# Altium schematic sheet Main Board-main_board_control-v2.0.0.SchDoc("Main Board-main_board_control-v2.0.0")
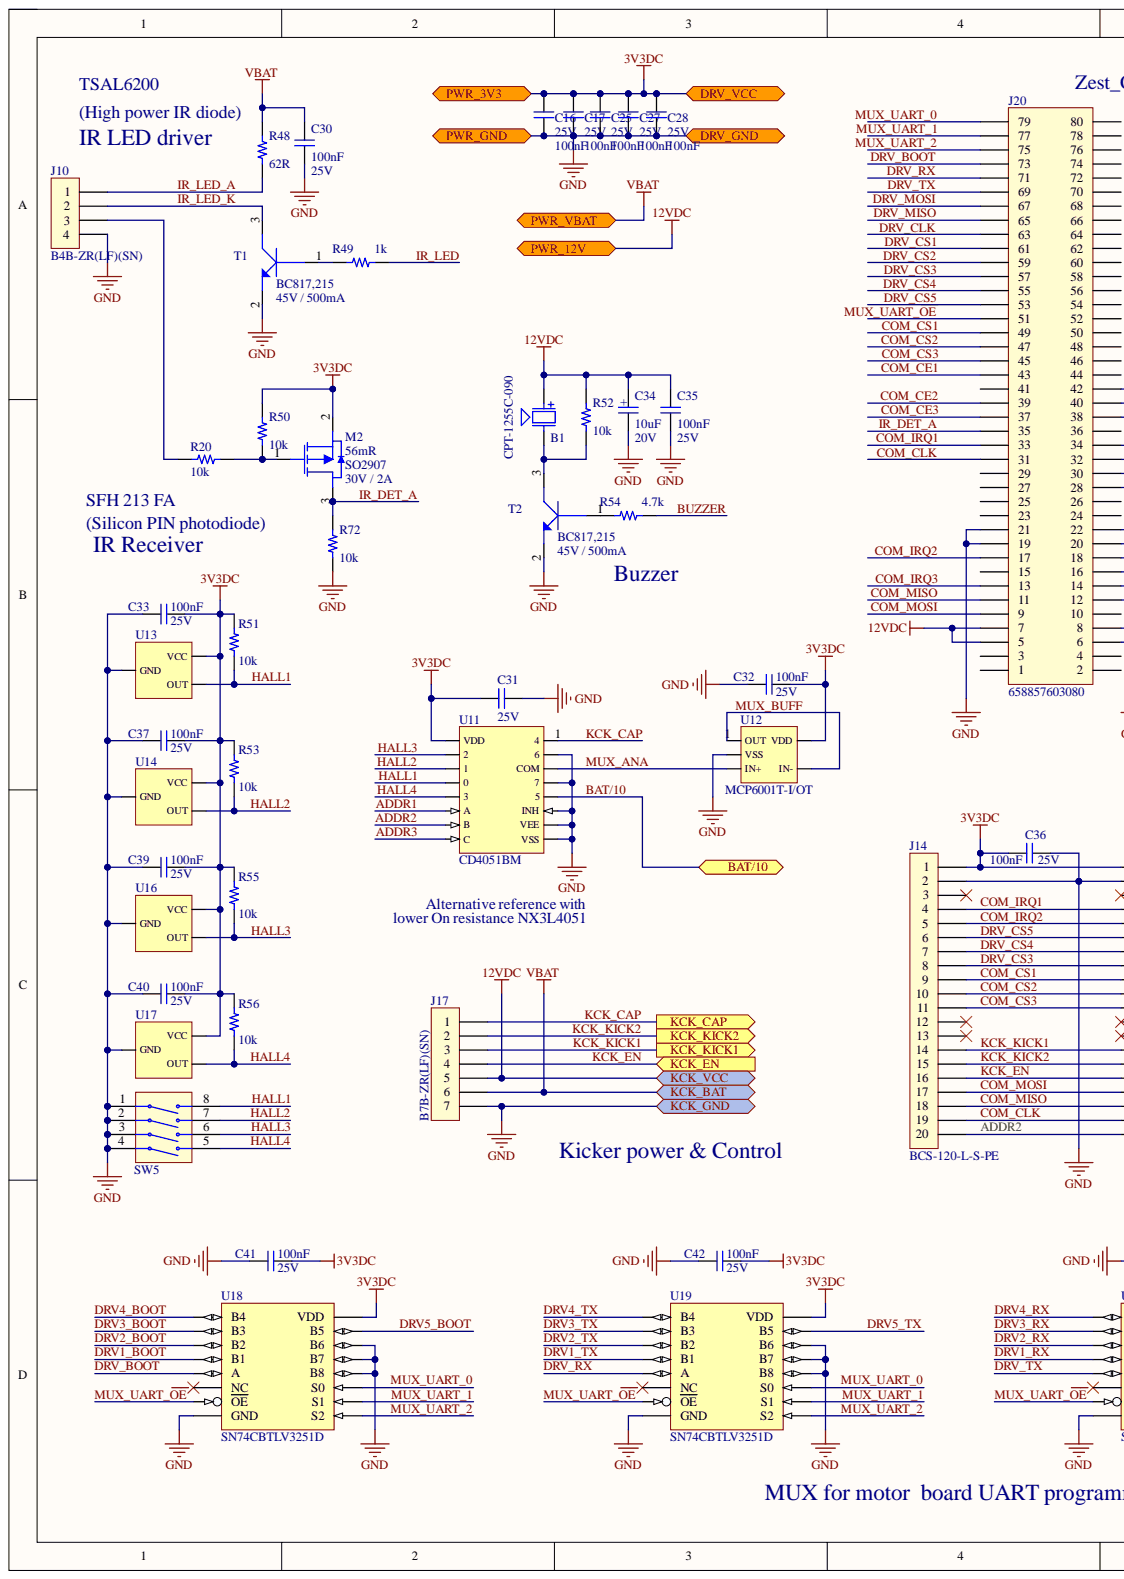
[diagram p0: Main Board-main_board_control-v2.0.0.SchDoc, left]
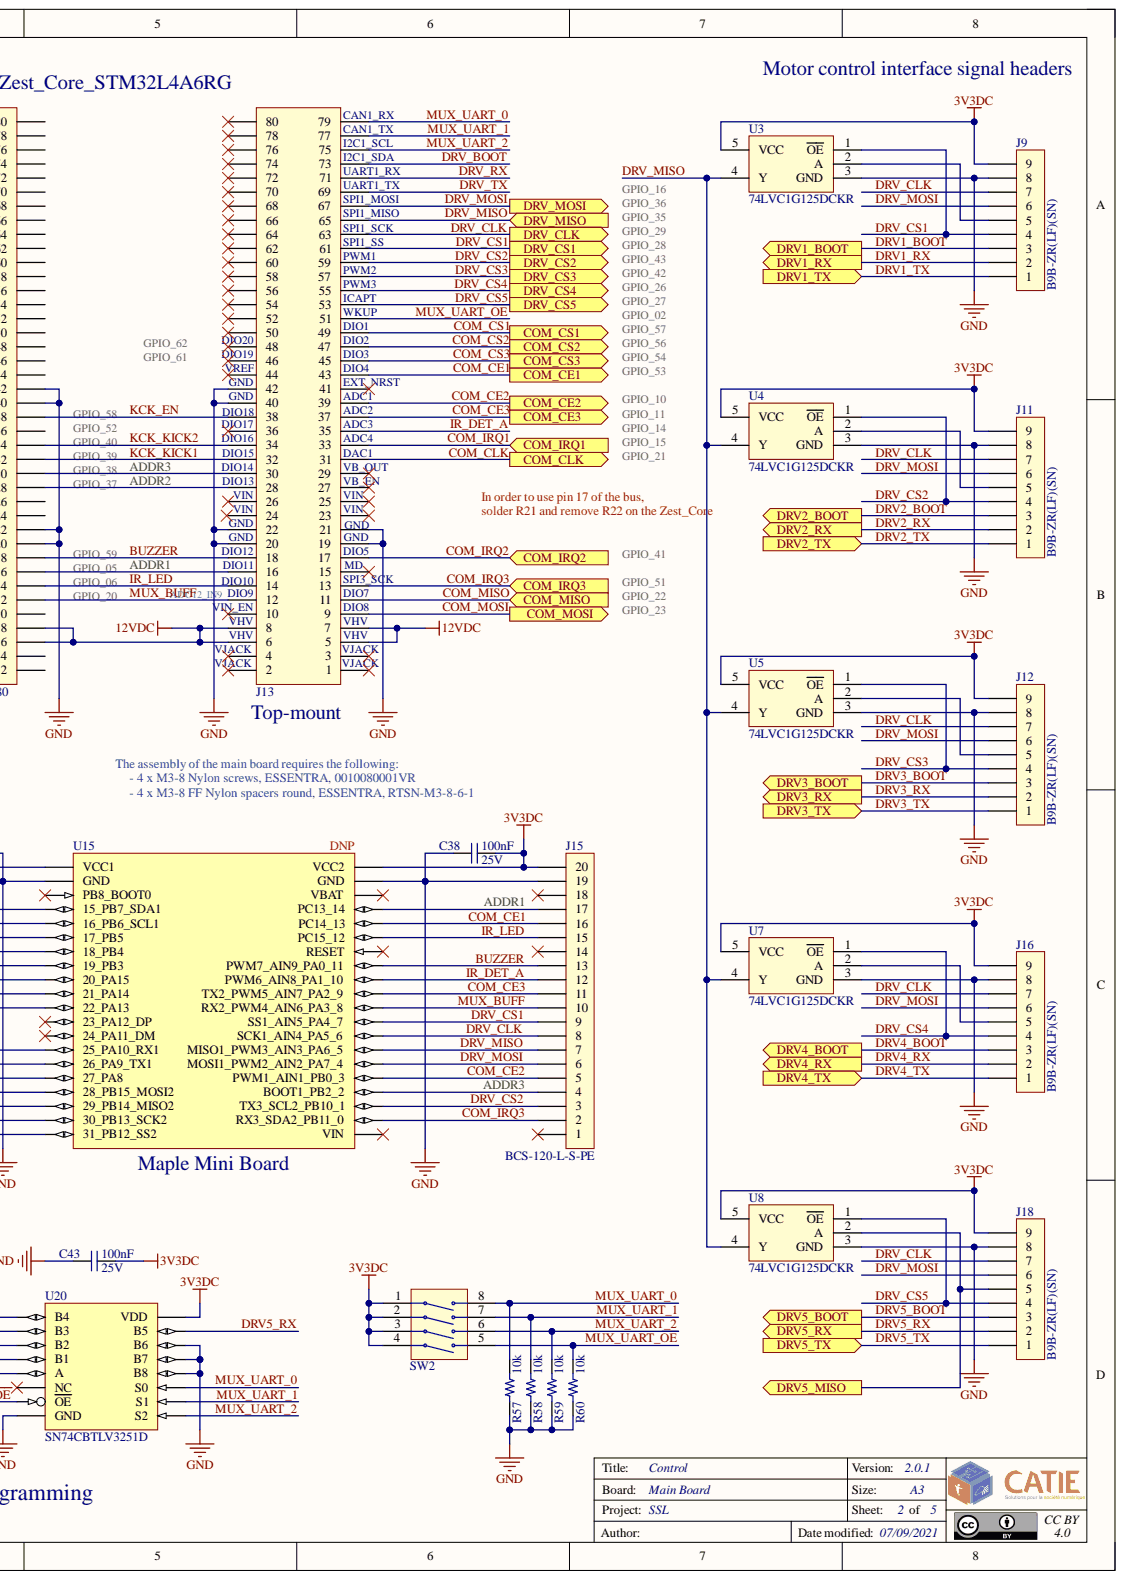
[diagram p1: Main Board-main_board_control-v2.0.0.SchDoc, right]

## Parts
B1  Reference: CPT-1255C-090  [BUZZER_CPT-1255C-090 _PIEZO_12MM]  (hidden comment: BUZZER_CPT-1255C-090 _PIEZO_12MM)
C16  value 100nF  Rating: 25V  [CAP_CER_0603]  (hidden comment: CAP_CER_0603)
C17  value 100nF  Rating: 25V  [CAP_CER_0603]  (hidden comment: CAP_CER_0603)
C25  value 100nF  Rating: 25V  [CAP_CER_0603]  (hidden comment: CAP_CER_0603)
C27  value 100nF  Rating: 25V  [CAP_CER_0603]  (hidden comment: CAP_CER_0603)
C28  value 100nF  Rating: 25V  [CAP_CER_0603]  (hidden comment: CAP_CER_0603)
C30  value 100nF  Rating: 25V  [CAP_CER_0603]  (hidden comment: CAP_CER_0603)
C31  Rating: 25V  [CAP_CER_0603]  (hidden comment: CAP_CER_0603)
C32  value 100nF  Rating: 25V  [CAP_CER_0603]  (hidden comment: CAP_CER_0603)
C33  value 100nF  Rating: 25V  [CAP_CER_0603]  (hidden comment: CAP_CER_0603)
C34  value 10uF  Rating: 20V  [CAP_TAN_A (1206)]  (hidden comment: CAP_TAN_A)
C35  value 100nF  Rating: 25V  [CAP_CER_0603]  (hidden comment: CAP_CER_0603)
C36  value 100nF  Rating: 25V  [CAP_CER_0603]  (hidden comment: CAP_CER_0603)
C37  value 100nF  Rating: 25V  [CAP_CER_0603]  (hidden comment: CAP_CER_0603)
C38  value 100nF  Rating: 25V  [CAP_CER_0603]  (hidden comment: CAP_CER_0603)
C39  value 100nF  Rating: 25V  [CAP_CER_0603]  (hidden comment: CAP_CER_0603)
C40  value 100nF  Rating: 25V  [CAP_CER_0603]  (hidden comment: CAP_CER_0603)
C41  value 100nF  Rating: 25V  [CAP_CER_0603]  (hidden comment: CAP_CER_0603)
C42  value 100nF  Rating: 25V  [CAP_CER_0603]  (hidden comment: CAP_CER_0603)
C43  value 100nF  Rating: 25V  [CAP_CER_0603]  (hidden comment: CAP_CER_0603)
J9  Reference: B9B-ZR(LF)(SN)  [HEADER_JST_B9B-ZR_1.5MM-PITCH_9-PIN_TOP]  (hidden comment: HEADER_JST_B9B-ZR_1.5MM-PITCH_9-PIN_TOP)
J10  Reference: B4B-ZR(LF)(SN)  [HEADER_JST_B4B-ZR_1.5MM-PITCH_4-PIN_TOP]  (hidden comment: HEADER_JST_B4B-ZR_1.5MM-PITCH_4-PIN_TOP)
J11  Reference: B9B-ZR(LF)(SN)  [HEADER_JST_B9B-ZR_1.5MM-PITCH_9-PIN_TOP]  (hidden comment: HEADER_JST_B9B-ZR_1.5MM-PITCH_9-PIN_TOP)
J12  Reference: B9B-ZR(LF)(SN)  [HEADER_JST_B9B-ZR_1.5MM-PITCH_9-PIN_TOP]  (hidden comment: HEADER_JST_B9B-ZR_1.5MM-PITCH_9-PIN_TOP)
J13  HEADER_FCI_10144517-081802LF_RECEPTACLE_3.7mm_6TRON  [HEADER_FCI_10144517-081802LF_RECEPTACLE_3.7mm_6TRON]  (value not drawn)
J14  Reference: BCS-120-L-S-PE  [HEADER_SAMTEC_BCS-120-L-S-PE_SOCKET_.54MM-PITCH_20-PIN]  (hidden comment: HEADER_SAMTEC_BCS-120-L-S-PE_SOCKET_.54MM-PITCH_20-PIN)
J15  Reference: BCS-120-L-S-PE  [HEADER_SAMTEC_BCS-120-L-S-PE_SOCKET_.54MM-PITCH_20-PIN]  (hidden comment: HEADER_SAMTEC_BCS-120-L-S-PE_SOCKET_.54MM-PITCH_20-PIN)
J16  Reference: B9B-ZR(LF)(SN)  [HEADER_JST_B9B-ZR_1.5MM-PITCH_9-PIN_TOP]  (hidden comment: HEADER_JST_B9B-ZR_1.5MM-PITCH_9-PIN_TOP)
J17  Reference: B7B-ZR(LF)(SN)  [HEADER_JST_B7B-ZR_1.5MM-PITCH_7-PIN_TOP]  (hidden comment: HEADER_JST_B7B-ZR_1.5MM-PITCH_7-PIN_TOP)
J18  Reference: B9B-ZR(LF)(SN)  [HEADER_JST_B9B-ZR_1.5MM-PITCH_9-PIN_TOP]  (hidden comment: HEADER_JST_B9B-ZR_1.5MM-PITCH_9-PIN_TOP)
J20  Reference: 658857603080  [HEADER_WE_658857603080_PLUG_7.6mm]  (hidden comment: HEADER_WE_658857603080_PLUG_7.6mm)
M2  value 56mR  Rating: 30V / 2A  Reference: SO2907  [TR_MOSFET-P_ST_STR2P3LLH6_SOT233]  (hidden comment: TR_MOSFET-P_ST_STR2P3LLH6_SOT233)
R20  value 10k  [RES_0603]  (hidden comment: RES_0603)
R48  value 62R  [RES_0603]  (hidden comment: RES_0603)
R49  value 1k  [RES_0603]  (hidden comment: RES_0603)
R50  value 10k  [RES_0603]  (hidden comment: RES_0603)
R51  value 10k  [RES_0603]  (hidden comment: RES_0603)
R52  value 10k  [RES_0603]  (hidden comment: RES_0603)
R53  value 10k  [RES_0603]  (hidden comment: RES_0603)
R54  value 4.7k  [RES_0603]  (hidden comment: RES_0603)
R55  value 10k  [RES_0603]  (hidden comment: RES_0603)
R56  value 10k  [RES_0603]  (hidden comment: RES_0603)
R57  value 10k  [RES_0603]  (hidden comment: RES_0603)
R58  value 10k  [RES_0603]  (hidden comment: RES_0603)
R59  value 10k  [RES_0603]  (hidden comment: RES_0603)
R60  value 10k  [RES_0603]  (hidden comment: RES_0603)
R72  value 10k  [RES_0603]  (hidden comment: RES_0603)
SW2  SWITCH_SMD 418121270804_WE_QUAD  [SWITCH_SMD 418121270804_WE_QUAD]  (value not drawn)
SW5  SWITCH_SMD 418121270804_WE_QUAD  [SWITCH_SMD 418121270804_WE_QUAD]  (value not drawn)
T1  Rating: 45V / 500mA  Reference: BC817,215  [TR_BC817_NPN_SOT23-3]  (hidden comment: TR_BC817_NPN_SOT23-3)
T2  Rating: 45V / 500mA  Reference: BC817,215  [TR_BC817_NPN_SOT23-3]  (hidden comment: TR_BC817_NPN_SOT23-3)
U3  Reference: 74LVC1G125DCKR  [IC_74LVC1G125DCK_SOT353_SC70-5]  (hidden comment: IC_74LVC1G125DCK_SOT353_SC70-5)
U4  Reference: 74LVC1G125DCKR  [IC_74LVC1G125DCK_SOT353_SC70-5]  (hidden comment: IC_74LVC1G125DCK_SOT353_SC70-5)
U5  Reference: 74LVC1G125DCKR  [IC_74LVC1G125DCK_SOT353_SC70-5]  (hidden comment: IC_74LVC1G125DCK_SOT353_SC70-5)
U7  Reference: 74LVC1G125DCKR  [IC_74LVC1G125DCK_SOT353_SC70-5]  (hidden comment: IC_74LVC1G125DCK_SOT353_SC70-5)
U8  Reference: 74LVC1G125DCKR  [IC_74LVC1G125DCK_SOT353_SC70-5]  (hidden comment: IC_74LVC1G125DCK_SOT353_SC70-5)
U11  Reference: CD4051BM  [IC_CD4051BM_TI_ANALOG_MUX_SOIC16]  (hidden comment: IC_CD4051BM_TI_ANALOG_MUX_SOIC16)
U12  Reference: MCP6001T-I/OT  [IC_MCP6001_OPAMP_RRIO_SOT23-5]  (hidden comment: IC_MCP6001_OPAMP_RRIO_SOT23-5)
U13  IC_DRV5023_TEXAS_HALL_SWITCH_SENSOR_SOT233  [IC_DRV5023_TEXAS_HALL_SWITCH_SENSOR_SOT233]  (value not drawn)
U14  IC_DRV5023_TEXAS_HALL_SWITCH_SENSOR_SOT233  [IC_DRV5023_TEXAS_HALL_SWITCH_SENSOR_SOT233]  (value not drawn)
U15  Mount: DNP  [MODULE_MAPLE-MINI_V2]  (hidden comment: MODULE_MAPLE-MINI)
U16  IC_DRV5023_TEXAS_HALL_SWITCH_SENSOR_SOT233  [IC_DRV5023_TEXAS_HALL_SWITCH_SENSOR_SOT233]  (value not drawn)
U17  IC_DRV5023_TEXAS_HALL_SWITCH_SENSOR_SOT233  [IC_DRV5023_TEXAS_HALL_SWITCH_SENSOR_SOT233]  (value not drawn)
U18  Reference: SN74CBTLV3251D  [IC_SN74CBTLV3251D_Multiplexer-DEMULTIPLEXER_8-CHANNEL_SOIC16]  (hidden comment: IC_SN74CBTLV3251D_Multiplexer-DEMULTIPLEXER_8-CHANNEL_SOIC16)
U19  Reference: SN74CBTLV3251D  [IC_SN74CBTLV3251D_Multiplexer-DEMULTIPLEXER_8-CHANNEL_SOIC16]  (hidden comment: IC_SN74CBTLV3251D_Multiplexer-DEMULTIPLEXER_8-CHANNEL_SOIC16)
U20  Reference: SN74CBTLV3251D  [IC_SN74CBTLV3251D_Multiplexer-DEMULTIPLEXER_8-CHANNEL_SOIC16]  (hidden comment: IC_SN74CBTLV3251D_Multiplexer-DEMULTIPLEXER_8-CHANNEL_SOIC16)

## Ports
BAT/10, COM_CE1, COM_CE2, COM_CE3, COM_CLK, COM_CS1, COM_CS2, COM_CS3, COM_IRQ1, COM_IRQ2, COM_IRQ3, COM_MISO, COM_MOSI, DRV1_BOOT, DRV1_RX, DRV1_TX, DRV2_BOOT, DRV2_RX, DRV2_TX, DRV3_BOOT, DRV3_RX, DRV3_TX, DRV4_BOOT, DRV4_RX, DRV4_TX, DRV5_BOOT, DRV5_MISO, DRV5_RX, DRV5_TX, DRV_CLK, DRV_CS1, DRV_CS2, DRV_CS3, DRV_CS4, DRV_CS5, DRV_GND, DRV_MISO, DRV_MOSI, DRV_VCC, KCK_BAT, KCK_CAP, KCK_EN, KCK_GND, KCK_KICK1, KCK_KICK2, KCK_VCC, PWR_3V3, PWR_12V, PWR_GND, PWR_VBAT

## Nets
3V3DC: C16.1 C17.1 C25.1 C27.1 C28.1 C31.1 C32.1 C33.1 C36.1 C37.1 C38.1 C39.1 C40.1 C41.1 C42.1 C43.1 J9.9 J11.9 J12.9 J14.1 J15.20 J16.9 J18.9 M2.2 R50.2 R51.2 R53.2 R55.2 R56.2 SW2.1 SW2.2 SW2.3 SW2.4 U3.5(VCC) U4.5(VCC) U5.5(VCC) U7.5(VCC) U8.5(VCC) U11.16(VDD) U12.5(VDD) U13.1 U14.1 U15.1(VCC1) U15.40(VCC2) U16.1 U17.1 U18.16(VDD) U19.16(VDD) U20.16(VDD) ; port DRV_VCC, port PWR_3V3
12VDC: B1.1 C34.2 C35.2 J13.5 J13.6 J13.7 J13.8 J17.5 J20.5 J20.6 J20.7 J20.8 R52.2 ; port KCK_VCC, port PWR_12V
ADDR1: J13.16 J15.17 J20.16 U11.11(A) U15.37(PC13_14)
ADDR2: J13.28 J14.20 J20.28 U11.10(B) U15.20(31_PB12_SS2)
ADDR3: J13.30 J15.4 J20.30 U11.9(C) U15.24(BOOT1_PB2_2)
BAT/10: U11.5 ; port BAT/10
BUZZER: J13.18 J15.13 J20.18 R54.2 U15.33(PWM7_AIN9_PA0_11)
COM_CE1: J13.43 J15.16 J20.43 U15.36(PC14_13) ; port COM_CE1
COM_CE2: J13.39 J15.5 J20.39 U15.25(PWM1_AIN1_PB0_3) ; port COM_CE2
COM_CE3: J13.37 J15.11 J20.37 U15.31(TX2_PWM5_AIN7_PA2_9) ; port COM_CE3
COM_CLK: J13.31 J14.19 J20.31 U15.19(30_PB13_SCK2) ; port COM_CLK
COM_CS1: J13.49 J14.9 J20.49 U15.9(20_PA15) ; port COM_CS1
COM_CS2: J13.47 J14.10 J20.47 U15.10(21_PA14) ; port COM_CS2
COM_CS3: J13.45 J14.11 J20.45 U15.11(22_PA13) ; port COM_CS3
COM_IRQ1: J13.33 J14.4 J20.33 U15.4(15_PB7_SDA1) ; port COM_IRQ1
COM_IRQ2: J13.17 J14.5 J20.17 U15.5(16_PB6_SCL1) ; port COM_IRQ2
COM_IRQ3: J13.13 J15.2 J20.13 U15.22(RX3_SDA2_PB11_0) ; port COM_IRQ3
COM_MISO: J13.11 J14.18 J20.11 U15.18(29_PB14_MISO2) ; port COM_MISO
COM_MOSI: J13.9 J14.17 J20.9 U15.17(28_PB15_MOSI2) ; port COM_MOSI
DRV1_BOOT: J9.3 U18.4(B1) ; port DRV1_BOOT
DRV1_RX: J9.2 U20.4(B1) ; port DRV1_RX
DRV1_TX: J9.1 U19.4(B1) ; port DRV1_TX
DRV2_BOOT: J11.3 U18.3(B2) ; port DRV2_BOOT
DRV2_RX: J11.2 U20.3(B2) ; port DRV2_RX
DRV2_TX: J11.1 U19.3(B2) ; port DRV2_TX
DRV3_BOOT: J12.3 U18.2(B3) ; port DRV3_BOOT
DRV3_RX: J12.2 U20.2(B3) ; port DRV3_RX
DRV3_TX: J12.1 U19.2(B3) ; port DRV3_TX
DRV4_BOOT: J16.3 U18.1(B4) ; port DRV4_BOOT
DRV4_RX: J16.2 U20.1(B4) ; port DRV4_RX
DRV4_TX: J16.1 U19.1(B4) ; port DRV4_TX
DRV5_BOOT: J18.3 U18.15(B5) ; port DRV5_BOOT
DRV5_MISO: J18.5 U8.2(A) ; port DRV5_MISO
DRV5_RX: J18.2 U20.15(B5) ; port DRV5_RX
DRV5_TX: J18.1 U19.15(B5) ; port DRV5_TX
DRV_BOOT: J13.73 J20.73 U18.5(A)
DRV_CLK: J9.7 J11.7 J12.7 J13.63 J15.8 J16.7 J18.7 J20.63 U15.28(SCK1_AIN4_PA5_6) ; port DRV_CLK
DRV_CS1: J9.4 J13.61 J15.9 J20.61 U3.1(OE) U15.29(SS1_AIN5_PA4_7) ; port DRV_CS1
DRV_CS2: J11.4 J13.59 J15.3 J20.59 U4.1(OE) U15.23(TX3_SCL2_PB10_1) ; port DRV_CS2
DRV_CS3: J12.4 J13.57 J14.8 J20.57 U5.1(OE) U15.8(19_PB3) ; port DRV_CS3
DRV_CS4: J13.55 J14.7 J16.4 J20.55 U7.1(OE) U15.7(18_PB4) ; port DRV_CS4
DRV_CS5: J13.53 J14.6 J18.4 J20.53 U8.1(OE) U15.6(17_PB5) ; port DRV_CS5
DRV_MISO: J13.65 J15.7 J20.65 U3.4(Y) U4.4(Y) U5.4(Y) U7.4(Y) U8.4(Y) U15.27(MISO1_PWM3_AIN3_PA6_5) ; port DRV_MISO
DRV_MOSI: J9.6 J11.6 J12.6 J13.67 J15.6 J16.6 J18.6 J20.67 U15.26(MOSI1_PWM2_AIN2_PA7_4) ; port DRV_MOSI
DRV_RX: J13.71 J20.71 U19.5(A)
DRV_TX: J13.69 J20.69 U20.5(A)
GND: C16.2 C17.2 C25.2 C27.2 C28.2 C30.1 C31.2 C32.2 C33.2 C34.1 C35.1 C36.2 C37.2 C38.2 C39.2 C40.2 C41.2 C42.2 C43.2 J9.8 J10.4 J11.8 J12.8 J13.19 J13.20 J13.21 J13.22 J13.40 J13.42 J14.2 J15.19 J16.8 J17.7 J18.8 J20.19 J20.20 J20.21 J20.22 J20.40 J20.42 R57.1 R58.1 R59.1 R60.1 R72.1 SW5.1 SW5.2 SW5.3 SW5.4 T1.2 T2.2 U3.3(GND) U4.3(GND) U5.3(GND) U7.3(GND) U8.3(GND) U11.2 U11.4 U11.6(INH) U11.7(VEE) U11.8(VSS) U12.2(VSS) U13.3 U14.3 U15.2(GND) U15.39(GND) U16.3 U17.3 U18.8(GND) U18.12(B8) U18.13(B7) U18.14(B6) U19.8(GND) U19.12(B8) U19.13(B7) U19.14(B6) U20.8(GND) U20.12(B8) U20.13(B7) U20.14(B6) ; port DRV_GND, port KCK_GND, port PWR_GND
HALL1: R51.1 SW5.8 U11.13 U13.2
HALL2: R53.1 SW5.7 U11.14 U14.2
HALL3: R55.1 SW5.6 U11.15 U16.2
HALL4: R56.1 SW5.5 U11.12 U17.2
IR_DET_A: J13.35 J15.12 J20.35 M2.3 R72.2 U15.32(PWM6_AIN8_PA1_10)
IR_LED: J13.14 J15.15 J20.14 R49.2 U15.35(PC15_12)
IR_LED_A: J10.1 R48.1
KCK_CAP: J17.1 U11.1 ; port KCK_CAP
KCK_EN: J13.38 J14.16 J17.4 J20.38 U15.16(27_PA8) ; port KCK_EN
KCK_KICK1: J13.32 J14.14 J17.3 J20.32 U15.14(25_PA10_RX1) ; port KCK_KICK1
KCK_KICK2: J13.34 J14.15 J17.2 J20.34 U15.15(26_PA9_TX1) ; port KCK_KICK2
MUX_ANA: U11.3(COM) U12.3(IN+)
MUX_BUFF: J13.12 J15.10 J20.12 U12.1(OUT) U12.4(IN-) U15.30(RX2_PWM4_AIN6_PA3_8)
MUX_UART_0: J13.79 J20.79 R57.2 SW2.8 U18.11(S0) U19.11(S0) U20.11(S0)
MUX_UART_1: J13.77 J20.77 R58.2 SW2.7 U18.10(S1) U19.10(S1) U20.10(S1)
MUX_UART_2: J13.75 J20.75 R59.2 SW2.6 U18.9(S2) U19.9(S2) U20.9(S2)
MUX_UART_~{OE}: J13.51 J20.51 R60.2 SW2.5 U18.7(OE) U19.7(OE) U20.7(OE)
VBAT: C30.2 J17.6 R48.2 ; port KCK_BAT, port PWR_VBAT
NetB1_2: B1.2 R52.1 T2.3
NetJ9_5: J9.5 U3.2(A)
NetJ10_2: J10.2 T1.3
NetJ10_3: J10.3 R20.2
NetJ11_5: J11.5 U4.2(A)
NetJ12_5: J12.5 U5.2(A)
NetJ16_5: J16.5 U7.2(A)
NetM2_1: M2.1 R20.1 R50.1
NetR49_1: R49.1 T1.1
NetR54_1: R54.1 T2.1

Not connected: J13 1 2 3 4 10 15 23 24 25 26 27 29 36 41 44 46 48 50 52 54 56 58 60 62 64 66 68 70 72 74 76 78 80; J14 3 12 13; J15 1 14 18; J20 1 2 3 4 10 15 23 24 25 26 27 29 36 41 44 46 48 50 52 54 56 58 60 62 64 66 68 70 72 74 76 78 80; U15 3(PB8_BOOT0) 12(23_PA12_DP) 13(24_PA11_DM) 21(VIN) 34(RESET) 38(VBAT); U18 6(NC); U19 6(NC); U20 6(NC)

## Library data of the parts
B1 = BUZZER_CPT-1255C-090 _PIEZO_12MM: Buzzer piezo TH D=12mm H=5mm 4kHz 20Vmax; Manufacturer: CUI; Rating: 20V; Case: 12mm; Component Kind: Standard; Library Name: MainBoard_Schlib.SchLib; Mount: Mnt; Technology: TRAD; Type: Buzzer; Value: 4kHz
C16 C17 C25 C27 C28 C30 C32 C33 C35-C43 = CAP_CER_0603: Capacitor ceramics SMD 0603; Manufacturer: Samsung; Ceram: X7R; Reference: CL10A104KA8NNNC; Tol: 10%; Case: 0603; Component Kind: Standard; Library Name: Schematic_Components.SchLib; Mount: Mnt; Technology: CMS; Type: Capacitor
C31 = CAP_CER_0603: Capacitor ceramics SMD 0603; Manufacturer: Samsung; Ceram: X7R; Reference: CL10A104KA8NNNC; Tol: 10%; Value: 100nF; Case: 0603; Component Kind: Standard; Library Name: Schematic_Components.SchLib; Mount: Mnt; Technology: CMS; Type: Capacitor
C34 = CAP_TAN_A: Capacitor Tantalum SMD Case A; Manufacturer: AVX; Reference: TCJA106M020R0150; Tol: 20%; Case: A; Component Kind: Standard; Library Name: Schematic_Components.SchLib; Mount: Mnt; Technology: CMS; Type: Capacitor
J9 J11 J12 J16 J18 = HEADER_JST_B9B-ZR_1.5MM-PITCH_9-PIN_TOP: Header JST B9B-ZR 1.5mm pitch 9-pin Top entry; Mating Housing: ZHR-9; Pre-criped cable 150mm: ASZHSZH28K152; Pre-criped cable 300mm: ASZHSZH28K305; Rating: 50V - 1A; Component Kind: Standard; Library Name: MainBoard_Schlib.SchLib; Manufacturer: JST; Mount: Mnt; Technology: TRAD; Type: Header; Value: 1.5mm
J10 = HEADER_JST_B4B-ZR_1.5MM-PITCH_4-PIN_TOP: Header JST B4B-ZR 1.5mm pitch 4-pin Top entry; Mating Housing: ZHR-4; Pre-criped cable 150mm: ASZHSZH28K152; Pre-criped cable 300mm: ASZHSZH28K305; Rating: 50V - 1A; Component Kind: Standard; Library Name: MainBoard_Schlib.SchLib; Manufacturer: JST; Mount: Mnt; Technology: TRAD; Type: Header; Value: 1.5mm
J13 = HEADER_FCI_10144517-081802LF_RECEPTACLE_3.7mm_6TRON: Header receptacle 80pin 0.8mm pitch 3.7mm high; Manufacturer: FCI/Amphenol; Rating: 0.5A / 100V; Component Kind: Standard; Gender: Receptacle; Hight: 3.7mm; Library Name: MainBoard_Schlib.SchLib; Mount: Mnt; Reference: 10144517-081802LF; Technology: CMS; Type: Header
J14 J15 = HEADER_SAMTEC_BCS-120-L-S-PE_SOCKET_.54MM-PITCH_20-PIN: Header socket 2.54mm pitch 20-pin pass-through entry; Manufacturer: Samtec; Rating: 475VAC - 4.6A; Component Kind: Standard; Library Name: MainBoard_Schlib.SchLib; Mount: Mnt; Technology: TRAD; Type: Header; Value: 2.54mm
J17 = HEADER_JST_B7B-ZR_1.5MM-PITCH_7-PIN_TOP: Header JST B7B-ZR 1.5mm pitch 7-pin Top entry; Mating Housing: ZHR-7; Pre-criped cable 150mm: ASZHSZH28K152; Pre-criped cable 300mm: ASZHSZH28K305; Rating: 50V - 1A; Component Kind: Standard; Library Name: MainBoard_Schlib.SchLib; Manufacturer: JST; Mount: Mnt; Technology: TRAD; Type: Header; Value: 1.5mm
J20 = HEADER_WE_658857603080_PLUG_7.6mm: Header plug 80pin 0.8mm pitch 7.6mm high; Manufacturer: Wurth Elektronik; Rating: 0.5A / 100V; Component Kind: Standard; Gender: Plug; Hight: 7.6mm; Library Name: Schematic_Components.SchLib; Mount: Mnt; Supplier 1: Digi-Key; Supplier Part Number 1: 732-11569-1-ND; Technology: CMS; Type: Header
M2 = TR_MOSFET-P_ST_STR2P3LLH6_SOT233: Transistor MOSFET-P SOT23-3 low-Rds_on; Manufacturer: ST Microelectronics; Case: SOT-23; Component Kind: Standard; Library Name: Schematic_Components.SchLib; Mount: Mnt; Technology: CMS; Type: Transistor
R20 R50-R53 R55-R60 R72 = RES_0603: Resistor SMD 0603; Manufacturer: Yageo; Rating: 0.1W; Reference: RC0603FR-0710KL; Tol: 1%; Case: 0603; Component Kind: Standard; Library Name: Schematic_Components.SchLib; Mount: Mnt; Technology: CMS; Type: Resistor
R48 = RES_0603: Resistor SMD 0603; Manufacturer: Yageo; Rating: 0.1W; Reference: RC0603FR-0762RL; Tol: 1%; Case: 0603; Component Kind: Standard; Library Name: Schematic_Components.SchLib; Mount: Mnt; Technology: CMS; Type: Resistor
R49 = RES_0603: Resistor SMD 0603; Manufacturer: Yageo; Rating: 0.1W; Reference: RC0603FR-071KL; Tol: 1%; Case: 0603; Component Kind: Standard; Library Name: Schematic_Components.SchLib; Mount: Mnt; Technology: CMS; Type: Resistor
R54 = RES_0603: Resistor SMD 0603; Manufacturer: Yageo; Rating: 0.1W; Reference: RC0603FR-074K7L; Tol: 1%; Case: 0603; Component Kind: Standard; Library Name: Schematic_Components.SchLib; Mount: Mnt; Technology: CMS; Type: Resistor
SW2 SW5 = SWITCH_SMD 418121270804_WE_QUAD: Quad SMT Switch compact 2.54mm-pitch; Manufacturer: Wurth Elektronik; Hight: 3.62mm; Rating: 24V/100mA; Component Kind: Standard; Library Name: MainBoard_Schlib.SchLib; Mount: Mnt; Reference: 418121270804; Technology: CMS; Type: Switch
T1 T2 = TR_BC817_NPN_SOT23-3: NPN transistor SOT23-3; Manufacturer: Nexperia; Component Kind: Standard; Library Name: MainBoard_Schlib.SchLib; Mount: Mnt; Technology: CMS; Type: Transistor
U3-U5 U7 U8 = IC_74LVC1G125DCK_SOT353_SC70-5: IC single bus buffer gate with 3-State output in SOT353 / SC70-5 case; Manufacturer: Texas Instruments; Rating: 32mA / 5V; Value: 3.3V; Component Kind: Standard; Library Name: Schematic_Components.SchLib; Mount: Mnt; Supplier 1: Digi-Key; Supplier Part Number 1: 296-11604-1-ND; Technology: CMS; Type: IC
U11 = IC_CD4051BM_TI_ANALOG_MUX_SOIC16: Analogue Multiplexer/demultiplexer 8x1 SOIC16; Manufacturer: Texas Instruments; Rating: 3V-20V; Value: 1kR; Case: SOIC16; Component Kind: Standard; Library Name: MainBoard_Schlib.SchLib; Mount: Mnt; Technology: CMS; Type: IC
U12 = IC_MCP6001_OPAMP_RRIO_SOT23-5: Single supply CMOS RRIO Op Amp in SOT23-5; Manufacturer: Microchip; Rating: 1.8-6V; Case: SOT23-5; Component Kind: Standard; Library Name: MainBoard_Schlib.SchLib; Mount: Mnt; Technology: CMS; Type: IC
U13 U14 U16 U17 = IC_DRV5023_TEXAS_HALL_SWITCH_SENSOR_SOT233: Digital switch Hall effect sensor SOT23-3; Manufacturer: Texas Instruments; Rating: 2.47V / 38V; Reference: DRV5023FAEDBZRQ1; Value: 3.5/2mT; Case: SOT23-3; Component Kind: Standard; Library Name: MainBoard_Schlib.SchLib; Mount: Mnt; Technology: CMS; Type: IC
U15 = MODULE_MAPLE-MINI: Value: 3.6V; Component Kind: Standard; Description: MODULE_MAPLE-MINI; Library Name: MainBoard_Schlib.SchLib; Technology: TRAD; Type: Module
U18-U20 = IC_SN74CBTLV3251D_Multiplexer-DEMULTIPLEXER_8-CHANNEL_SOIC16: Multiplexer/Demultiplexer low power SOIC16; Manufacturer: Texas Instruments; Value: 3.6V; Case: SOIC-16; Component Kind: Standard; Library Name: MainBoard_Schlib.SchLib; Mount: Mnt; Technology: CMS; Type: IC
